# Altium schematic sheet DAC.SchDoc
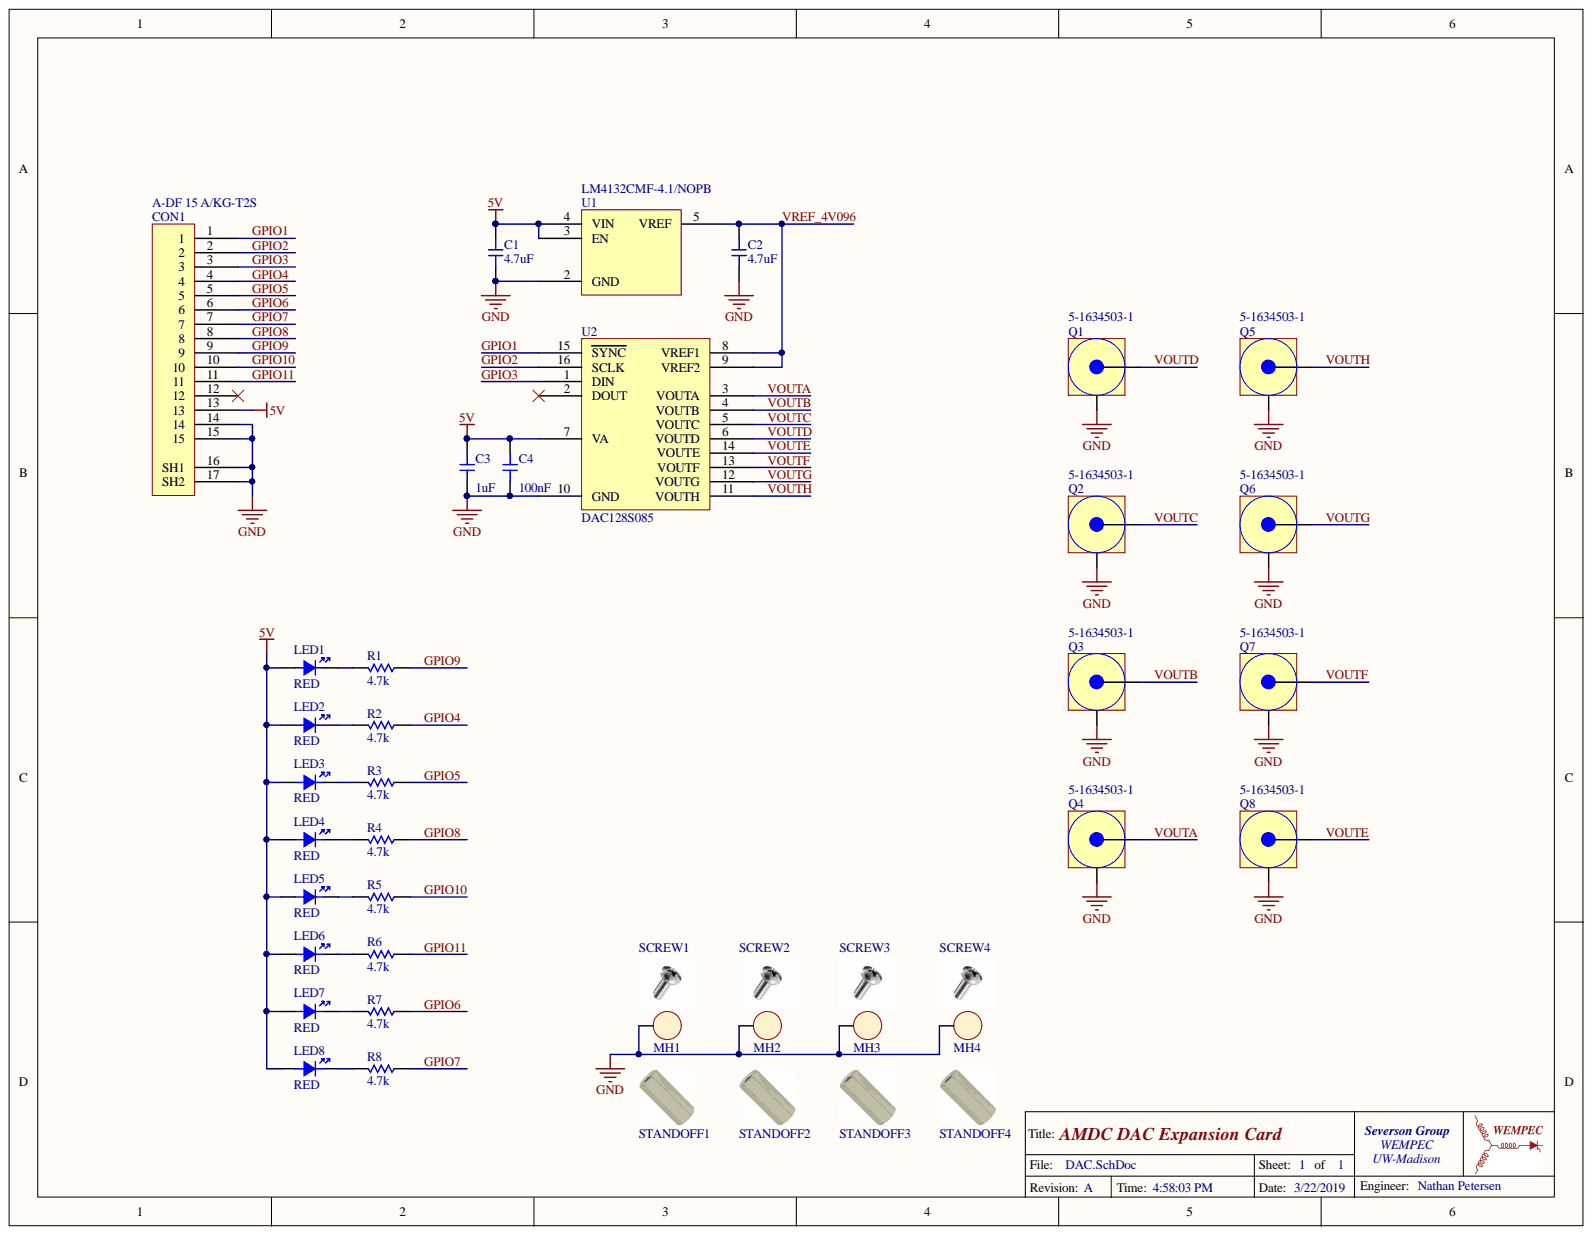
[diagram p0: DAC.SchDoc]

## Parts
C1  value 4.7uF  [0603-CAP]  (hidden comment: Capacitor)
C2  value 4.7uF  [0603-CAP]  (hidden comment: Capacitor)
C3  value 1uF  [0603-CAP]  (hidden comment: Capacitor)
C4  value 100nF  [0603-CAP]  (hidden comment: Capacitor)
CON1  A-DF 15 A/KG-T2S  [A-DF 15 A/KG-T2S]
LED1  LED  Color: RED  [0603-LED]
LED2  LED  Color: RED  [0603-LED]
LED3  LED  Color: RED  [0603-LED]
LED4  LED  Color: RED  [0603-LED]
LED5  LED  Color: RED  [0603-LED]
LED6  LED  Color: RED  [0603-LED]
LED7  LED  Color: RED  [0603-LED]
LED8  LED  Color: RED  [0603-LED]
MH1  MOUNT_HOLE  [MOUNTING_HOLE]  (value not drawn)  (no BOM)
MH2  MOUNT_HOLE  [MOUNTING_HOLE]  (value not drawn)  (no BOM)
MH3  MOUNT_HOLE  [MOUNTING_HOLE]  (value not drawn)  (no BOM)
MH4  MOUNT_HOLE  [MOUNTING_HOLE]  (value not drawn)  (no BOM)
Q1  5-1634503-1  [5-1634503-1]
Q2  5-1634503-1  [5-1634503-1]
Q3  5-1634503-1  [5-1634503-1]
Q4  5-1634503-1  [5-1634503-1]
Q5  5-1634503-1  [5-1634503-1]
Q6  5-1634503-1  [5-1634503-1]
Q7  5-1634503-1  [5-1634503-1]
Q8  5-1634503-1  [5-1634503-1]
R1  value 4.7k  [0603-RES]  (hidden comment: Resistor)
R2  value 4.7k  [0603-RES]  (hidden comment: Resistor)
R3  value 4.7k  [0603-RES]  (hidden comment: Resistor)
R4  value 4.7k  [0603-RES]  (hidden comment: Resistor)
R5  value 4.7k  [0603-RES]  (hidden comment: Resistor)
R6  value 4.7k  [0603-RES]  (hidden comment: Resistor)
R7  value 4.7k  [0603-RES]  (hidden comment: Resistor)
R8  value 4.7k  [0603-RES]  (hidden comment: Resistor)
SCREW1  Screw-4-40  (value not drawn)  (mechanical)
SCREW2  Screw-4-40  (value not drawn)  (mechanical)
SCREW3  Screw-4-40  (value not drawn)  (mechanical)
SCREW4  Screw-4-40  (value not drawn)  (mechanical)
STANDOFF1  Standoff-4-40  (value not drawn)  (mechanical)
STANDOFF2  Standoff-4-40  (value not drawn)  (mechanical)
STANDOFF3  Standoff-4-40  (value not drawn)  (mechanical)
STANDOFF4  Standoff-4-40  (value not drawn)  (mechanical)
U1  LM4132CMF-4.1/NOPB  [SOT95P280X145-5N]
U2  DAC128S085  [TSOP65P640X120-16N]

## Nets
5V: C1.1 C3.2 C4.2 CON1.13 LED1.A LED2.A LED3.A LED4.A LED5.A LED6.A LED7.A LED8.A U1.3(EN) U1.4(VIN) U2.7(VA)
GND: C1.2 C2.2 C3.1 C4.1 CON1.14 CON1.15 CON1.16(SH1) CON1.17(SH2) MH1.1 MH2.1 MH3.1 MH4.1 Q1.2 Q2.2 Q3.2 Q4.2 Q5.2 Q6.2 Q7.2 Q8.2 U1.2(GND) U2.10(GND)
GPIO1: CON1.1 U2.15(SYNC)
GPIO2: CON1.2 U2.16(SCLK)
GPIO3: CON1.3 U2.1(DIN)
GPIO4: CON1.4 R2.1
GPIO5: CON1.5 R3.1
GPIO6: CON1.6 R7.1
GPIO7: CON1.7 R8.1
GPIO8: CON1.8 R4.1
GPIO9: CON1.9 R1.1
GPIO10: CON1.10 R5.1
GPIO11: CON1.11 R6.1
VOUTA: Q4.1 U2.3(VOUTA)
VOUTB: Q3.1 U2.4(VOUTB)
VOUTC: Q2.1 U2.5(VOUTC)
VOUTD: Q1.1 U2.6(VOUTD)
VOUTE: Q8.1 U2.14(VOUTE)
VOUTF: Q7.1 U2.13(VOUTF)
VOUTG: Q6.1 U2.12(VOUTG)
VOUTH: Q5.1 U2.11(VOUTH)
VREF_4V096: C2.1 U1.5(VREF) U2.8(VREF1) U2.9(VREF2)
NetLED1_C: LED1.C R1.2
NetLED2_C: LED2.C R2.2
NetLED3_C: LED3.C R3.2
NetLED4_C: LED4.C R4.2
NetLED5_C: LED5.C R5.2
NetLED6_C: LED6.C R6.2
NetLED7_C: LED7.C R7.2
NetLED8_C: LED8.C R8.2

Not connected: CON1 12; U2 2(DOUT)

## Library data of the parts
CON1 = A-DF 15 A/KG-T2S: CONN D-SUB RCPT 15POS R/A SOLDER; Component Kind: Standard; Library Name: Schlib1.SchLib; Supplier 1: Digi-Key; Supplier Part Number 1: AE10929-ND
MH1-MH4 = MOUNT_HOLE: MOUNTING HOLE, 4-40; Library Name: Schlib1.SchLib
Q1-Q8 = 5-1634503-1: CONN BNC JACK STR 50 OHM PCB; Component Kind: Standard; Library Name: Schlib1.SchLib; Supplier 1: Digi-Key; Supplier Part Number 1: A97581-ND
U1 = LM4132CMF-4.1/NOPB: IC VREF SERIES 4.096V SOT23-5; Component Kind: Standard; Library Name: Schlib1.SchLib; Supplier 1: Digi-Key; Supplier Part Number 1: LM4132CMF-4.1/NOPBCT-ND
U2 = DAC128S085CIMT/NOPB: IC DAC 12BIT 8CH RR OUT 16TSSOP; Component Kind: Standard; Library Name: Schlib1.SchLib; Supplier 1: Digi-Key; Supplier Part Number 1: DAC128S085CIMT/NOPB-ND
C1-C4 = Capacitor: Component Kind: Standard; Description: Capacitor; Simulation: CAP; Supplier 1: Digi-Key; Library Name: AMDC.SchLib
  C1 C2: Supplier Part Number 1: 1276-1044-1-ND
  C3: Supplier Part Number 1: 1276-1182-1-ND
  C4: Supplier Part Number 1: 1276-1012-1-ND
LED1-LED8 = LED: Component Kind: Standard; Supplier 1: Digi-Key; Supplier Part Number 1: SML-D12U1WT86CT-ND; Library Name: AMDC.SchLib
R1-R8 = Resistor: Component Kind: Standard; Description: Resistor; Simulation: RES; Supplier 1: Digi-Key; Supplier Part Number 1: 311-4.7KGRCT-ND; Library Name: AMDC.SchLib
SCREW1-SCREW4 = Screw-4-40: Library Name: Schlib1.SchLib; Supplier 1: Digi-Key; Supplier Part Number 1: KEY1046-ND
STANDOFF1-STANDOFF4 = Standoff-4-40: Library Name: Schlib1.SchLib; Supplier 1: Digi-Key; Supplier Part Number 1: 36-1656-ND
